# Altium schematic sheet 2_XTAL_JTAG_FLASH (2).SchDoc("2_XTAL_JTAG_FLASH (2)")
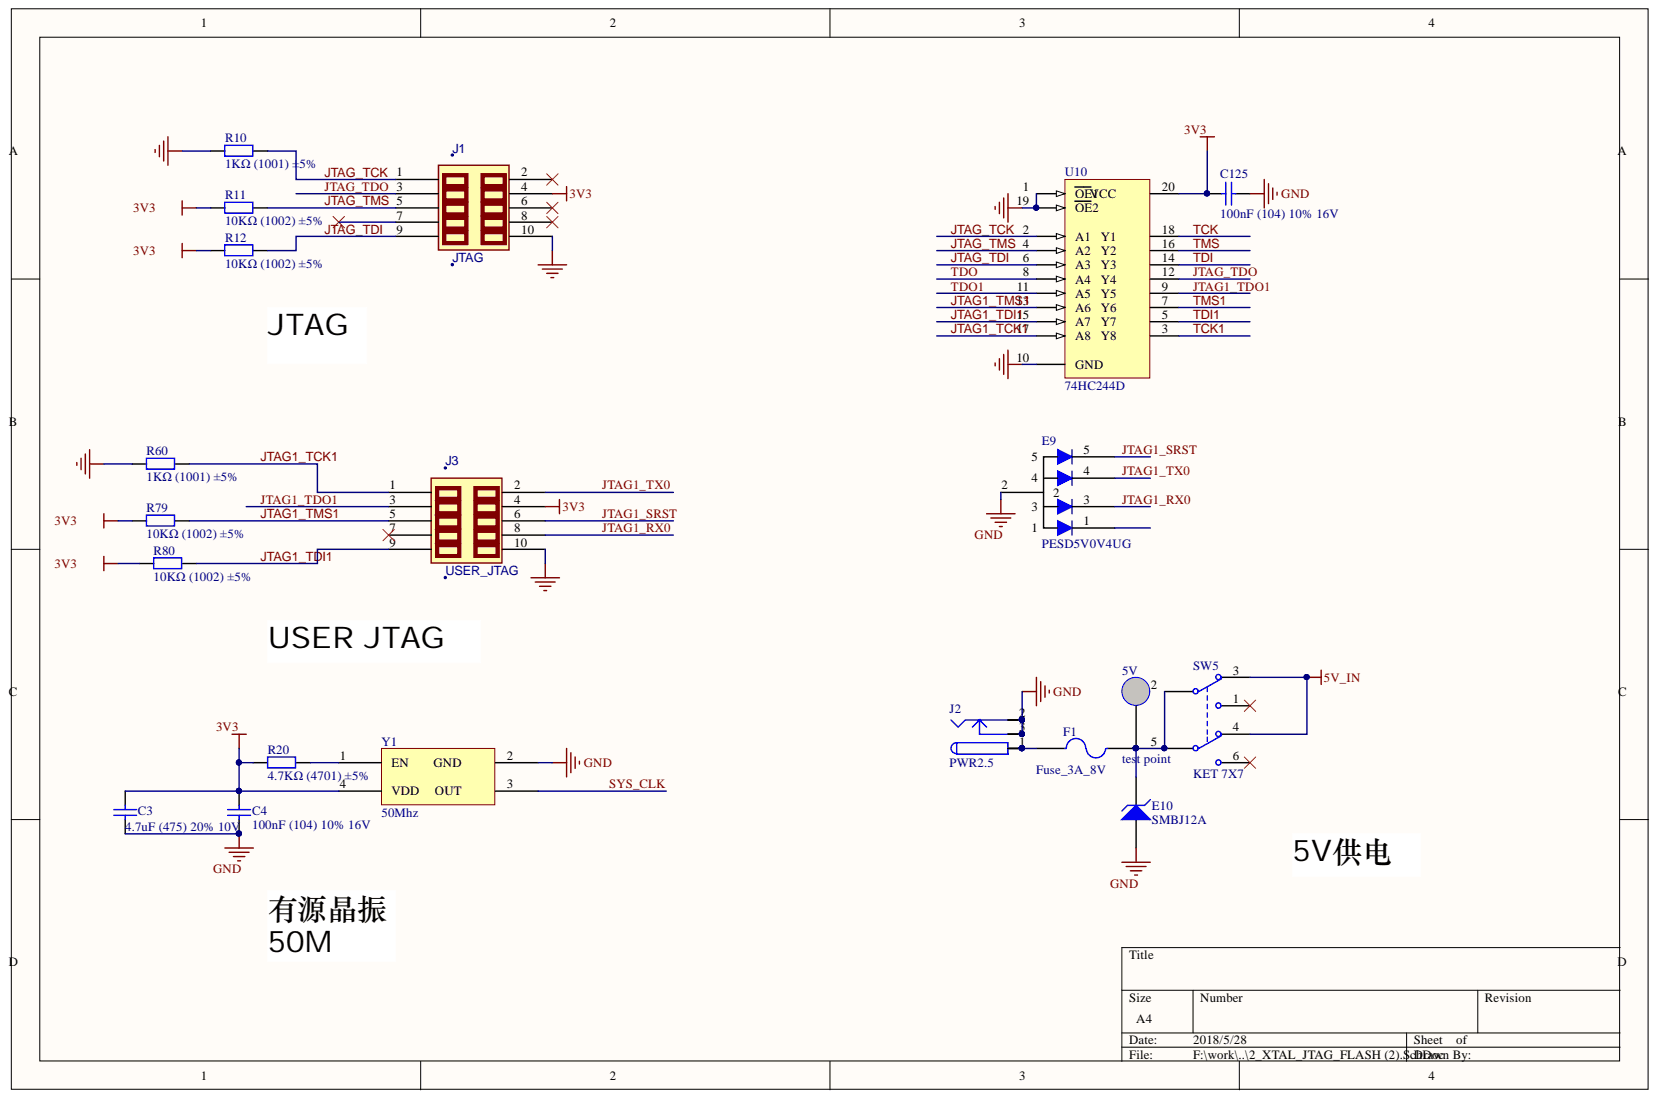
[diagram p0: 2_XTAL_JTAG_FLASH ]

## Parts
5V  test point  [TP_30mil]
C3  4.7uF (475) 20% 10V  [0402_C]
C4  100nF (104) 10% 16V  [0402_C]
C125  100nF (104) 10% 16V  [0402_C]
E9  PESD5V0V4UG  [SOT353]
E10  SMBJ12A  [SMB(DO-214AA)_S1]
F1  Fuse_3A_8V  [1812]
J1  JTAG  [DC-2.54-L-Z-10P]
J2  PWR2.5  [DC-005]
J3  USER_JTAG  [DC-2.54-L-Z-10P]
R10  1KΩ (1001) ±5%  [0402_R]
R11  10KΩ (1002) ±5%  [0402_R]
R12  10KΩ (1002) ±5%  [0402_R]
R20  4.7KΩ (4701) ±5%  [0402_R]
R60  1KΩ (1001) ±5%  [0402_R]
R79  10KΩ (1002) ±5%  [0402_R]
R80  10KΩ (1002) ±5%  [0402_R]
SW5  KET 7X7  [KFT DIP-7X7]
U10  74HC244D  [TSSOP20]
Y1  50Mhz  [SMDXTAL3225]

## Nets
3V3: C3.2 C4.2 C125.2 J1.4 J3.4 R11.1 R12.1 R20.1 R79.1 R80.1 U10.20 Y1.4(VDD)
5V_IN: SW5.3 SW5.4
GND: C3.1 C4.1 C125.1 E9.2 E10.1 J1.10 J2.2 J2.2A J2.2B J2.3 J2.3A J2.3B J3.10 R10.1 R60.1 U10.1(OE1) U10.10(GND) U10.19(OE2) Y1.2
JTAG1_RX0: E9.3 J3.8
JTAG1_SRST: E9.5 J3.6
JTAG1_TCK1: J3.1 R60.2 U10.17(A8)
JTAG1_TDI1: J3.9 R80.2 U10.15(A7)
JTAG1_TDO1: J3.3 U10.9
JTAG1_TMS1: J3.5 R79.2 U10.13(A6)
JTAG1_TX0: E9.4 J3.2
JTAG_TCK: J1.1 R10.2 U10.2(A1)
JTAG_TDI: J1.9 R12.2 U10.6(A3)
JTAG_TDO: J1.3 U10.12
JTAG_TMS: J1.5 R11.2 U10.4(A2)
SYS_CLK: Y1.3
TCK: U10.18
TCK1: U10.3
TDI: U10.14
TDI1: U10.5
TDO: U10.8(A4)
TDO1: U10.11(A5)
TMS: U10.16
TMS1: U10.7
Net5V_1: 5V.1 E10.2 F1.2 SW5.2 SW5.5
NetF1_1: F1.1 J2.1 J2.1A J2.1B
NetR20_2: R20.2 Y1.1(EN)

Not connected: E9 1; J1 2 6 7 8; J3 7; SW5 1 6

## Library data of the parts
5V = TP: Component Kind: Standard; Library Name: Personal_Accumulation_Sch.SchLib
C3 = 0402 4.7uF (475) 20% 10V: 贴片电容; Component Kind: Standard; ComponentLink1Description: 供应商链接; ComponentLink1URL: http://www.szlcsc.com/product/details_24469.html; Library Name: Miscellaneous Devices LC.IntLib; Package: 0402; Supplier: LC; SuppliersPartNumber: C23733
C4 C125 = 0402 100nF (104) 10% 16V: 贴片电容; Component Kind: Standard; ComponentLink1Description: 供应商链接; ComponentLink1URL: http://www.szlcsc.com/product/details_1877.html; Library Name: Miscellaneous Devices LC.IntLib; Package: 0402; Supplier: LC; SuppliersPartNumber: C1525
E9 = PESD*4: Component Kind: Standard; Library Name: Personal_Accumulation_Sch.SchLib
E10 = D Zener: ESD; Component Kind: Standard; LatestRevisionDate: 17-Jul-2002; LatestRevisionNote: Re-released for DXP Platform.; Library Name: Miscellaneous Devices.IntLib; PackageDescription: Diode, Thru-Hole; 2 Leads; 0.7 in Pin Spacing; PackageReference: DIODE-0.7; Published: 8-Jun-2000; Signal Integrity: D Zener; Simulation: ZENER; Publisher: Altium Limited
F1 = Fuse 2: Fuse保险丝; Component Kind: Standard; LatestRevisionDate: 17-Jul-2002; LatestRevisionNote: Re-released for DXP Platform.; Library Name: AX301_AX4010.IntLib; PackageDescription: Thru-Hole; 2 Leads; PackageReference: PIN-W2/E2.8; Published: 8-Jun-2000; Simulation: FUSE; Publisher: Altium Limited
J1 J3 = JTAG-10_Dup1: Component Kind: Standard
J2 = PWR2.5: DC-005电源插座孔径5.5mm内圆针2.5mm; Component Kind: Standard; ComponentLink1Description: Manufacturer Link; ComponentLink1URL: http://domino.kycon.com/internet/Products.html; DatasheetDocument: 09-May-2002; Library Name: Miscellaneous Connectors.IntLib; Published: 17-Dec-2003; Signal Integrity: Connector; Publisher: Altium Limited
R10 R60 = 0402 1KΩ (1001) 1%: 贴片电阻; Component Kind: Standard; ComponentLink1Description: 供应商链接; ComponentLink1URL: http://www.szlcsc.com/product/details_12256.html; Library Name: Miscellaneous Devices LC.IntLib; Package: 0402; Supplier: LC; SuppliersPartNumber: C11702
R11 R12 R79 R80 = 0402 10KΩ (1002) 1%: 贴片电阻; Component Kind: Standard; ComponentLink1Description: 供应商链接; ComponentLink1URL: http://www.szlcsc.com/product/details_26487.html; Library Name: Miscellaneous Devices LC.IntLib; Package: 0402; Supplier: LC; SuppliersPartNumber: C25744
R20 = 0402 4.7KΩ (4701) 1%: 贴片电阻; Component Kind: Standard; ComponentLink1Description: 供应商链接; ComponentLink1URL: http://www.szlcsc.com/product/details_26643.html; Library Name: Miscellaneous Devices LC.IntLib; Package: 0402; Supplier: LC; SuppliersPartNumber: C25900
SW5 = KET 7X7: 7*7自锁开关6脚; 试用产品: 如您满意请支持正品; BOM名称: 7*7自锁开关; Component Kind: Standard; 学习资源: www.ooocke.com; Library Name: 元件符号库.SchLib
U10 = 74HC244D_[C5622]: 74系列逻辑芯片; Component Kind: Standard; ComponentLink1Description: 供应商链接; ComponentLink1URL: http://www.szlcsc.com/product/details_6073.html; Library Name: Miscellaneous Devices LC.IntLib; Package: SOIC-20_300mil; Supplier: LC; SuppliersPartNumber: C5622
Y1 = XTAL4: 有源晶振50M; Component Kind: Standard; Library Name: XTAL.SchLib
